# Altium schematic sheet nixie-tap-b.SchDoc
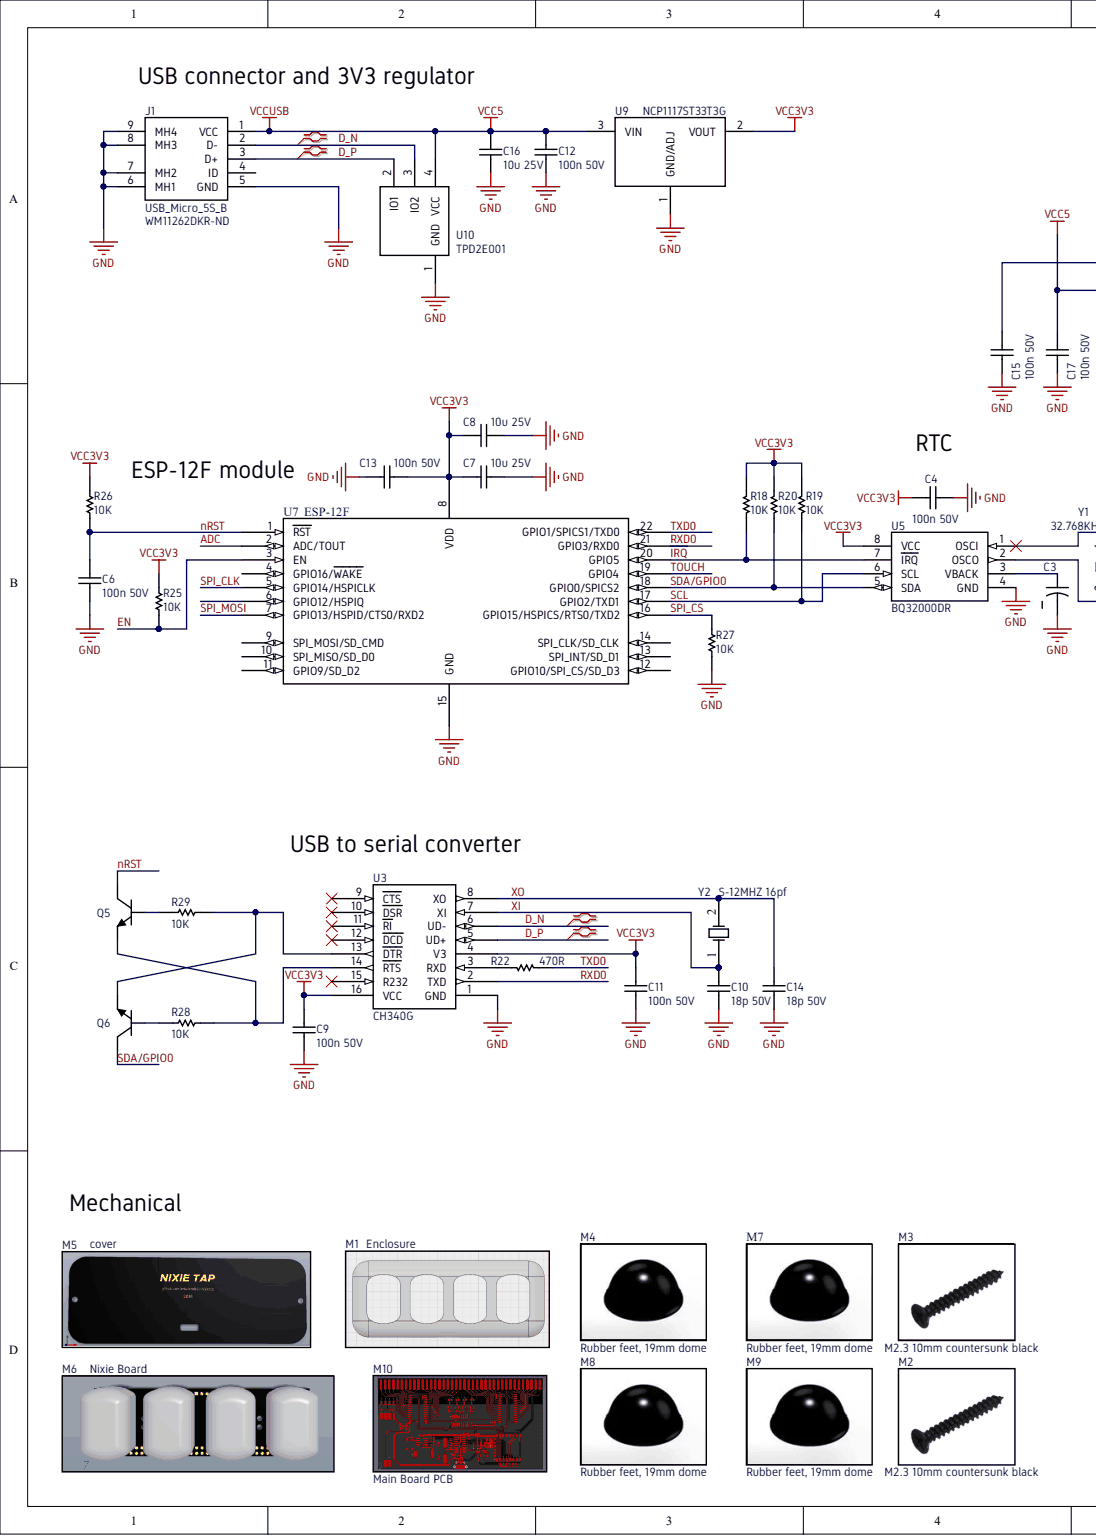
[diagram p0: nixie-tap-b.SchDoc, left]
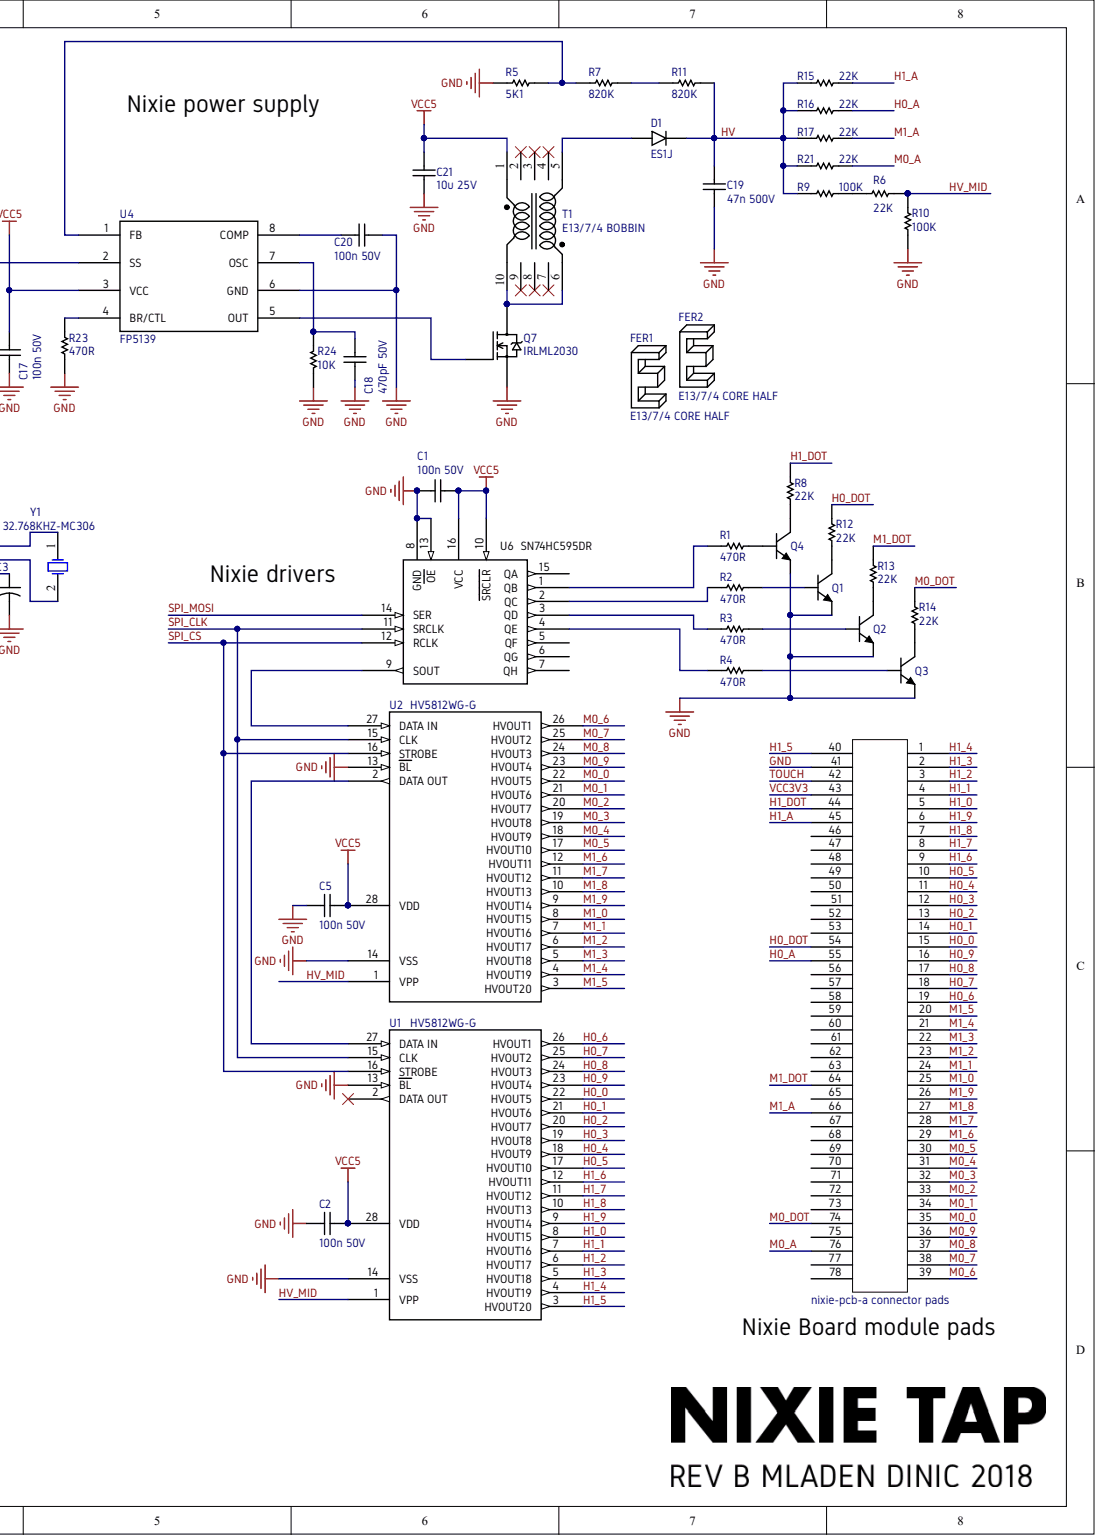
[diagram p1: nixie-tap-b.SchDoc, right]

## Parts
C1  100n 50V  value 100n  Voltage - Rated: 50V  [RESC2013X06L]
C2  100n 50V  value 100n  Voltage - Rated: 50V  [RESC2013X06L]
C3  ELNA DSK-3R3H224U-HL  [ELNA DSK-3R3H224U-HL]  (value not drawn)
C4  100n 50V  value 100n  Voltage - Rated: 50V  [RESC2013X06L]
C5  100n 50V  value 100n  Voltage - Rated: 50V  [RESC2013X06L]
C6  100n 50V  value 100n  [RESC2013X06L]
C7  10u 25V  Voltage - Rated: 25V  [RESC2013X06L]
C8  10u 25V  Voltage - Rated: 25V  [RESC2013X06L]
C9  100n 50V  value 100n  Voltage - Rated: 50V  [RESC2013X06L]
C10  18p 50V  Voltage - Rated: 50V  [RESC2013X06L]
C11  100n 50V  value 100n  Voltage - Rated: 50V  [RESC2013X06L]
C12  100n 50V  value 100n  [RESC2013X06L]
C13  100n 50V  value 100n  [RESC2013X06L]
C14  18p 50V  Voltage - Rated: 50V  [RESC2013X06L]
C15  100n 50V  value 100n  Voltage - Rated: 50V  [RESC2013X06L]
C16  10u 25V  [RESC2013X06L]
C17  100n 50V  value 100n  Voltage - Rated: 50V  [RESC2013X06L]
C18  470pF 50V  [RESC2013X06L]
C19  47n 500V  [CAP_1206]
C20  100n 50V  value 100n  Voltage - Rated: 50V  [RESC2013X06L]
C21  10u 25V  Voltage - Rated: 25V  [RESC2013X06L]
D1  ES1J  [DO-214AC]
FER1  E13/7/4 CORE HALF  (mechanical)
FER2  E13/7/4 CORE HALF  (mechanical)
J1  USB_Micro_5S_B  Supplier Part Number 1: WM11262DKR-ND  [USB_MICRO_5S_B]
M1  Enclosure  (mechanical)
M2  M2.3 10mm countersunk black  (mechanical)
M3  M2.3 10mm countersunk black  (mechanical)
M4  Rubber feet, 19mm dome  (mechanical)
M5  cover  (mechanical)
M6  Nixie Board  (mechanical)
M7  Rubber feet, 19mm dome  (mechanical)
M8  Rubber feet, 19mm dome  (mechanical)
M9  Rubber feet, 19mm dome  (mechanical)
M10  Main Board PCB  (mechanical)
Module1  nixie-pcb-a connector pads  [NB1.1]  (no BOM)
Q1  MMBTA42LT1G  [SOT95P245X110-3N]  (value not drawn)
Q2  MMBTA42LT1G  [SOT95P245X110-3N]  (value not drawn)
Q3  MMBTA42LT1G  [SOT95P245X110-3N]  (value not drawn)
Q4  MMBTA42LT1G  [SOT95P245X110-3N]  (value not drawn)
Q5  MMBTA42LT1G  [SOT95P245X110-3N]  (value not drawn)
Q6  MMBTA42LT1G  [SOT95P245X110-3N]  (value not drawn)
Q7  IRLML2030  [SOT_23]
R1  470R  [RESC2013X06L]
R2  470R  [RESC2013X06L]
R3  470R  [RESC2013X06L]
R4  470R  [RESC2013X06L]
R5  5K1  [RESC2013X06L]
R6  22K  [RESC2013X06L]
R7  820K  [RESC2013X06L]
R8  22K  [RESC2013X06L]
R9  100K  [RESC2013X06L]
R10  100K  [RESC2013X06L]
R11  820K  [RESC2013X06L]
R12  22K  [RESC2013X06L]
R13  22K  [RESC2013X06L]
R14  22K  [RESC2013X06L]
R15  22K  [RESC2013X06L]
R16  22K  [RESC2013X06L]
R17  22K  [RESC2013X06L]
R18  10K  [RESC2013X06L]
R19  10K  [RESC2013X06L]
R20  10K  [RESC2013X06L]
R21  22K  [RESC2013X06L]
R22  470R  [RESC2013X06L]
R23  470R  [RESC2013X06L]
R24  10K  [RESC2013X06L]
R25  10K  [RESC2013X06L]
R26  10K  [RESC2013X06L]
R27  10K  [RESC2013X06L]
R28  10K  [RESC2013X06L]
R29  10K  [RESC2013X06L]
T1  E13/7/4 BOBBIN  [E13/7/4]
U1  HV5812WG-G  [SOIC127P1030X265-28N]
U2  HV5812WG-G  [SOIC127P1030X265-28N]
U3  CH340G  [SOP-16]
U4  FP5139  [TSSOP8]
U5  BQ32000DR  [SOIC-8]
U6  SN74HC595DR  [SO16_M]
U7  ESP-12F  [ESP_12_E_L]
U9  NCP1117ST33T3G  [SOT-223]
U10  TPD2E001  [SOT190P237X120-4N]
Y1  Ordering Code: 32.768KHZ-MC306  [SOJ550P380X254-4M]  (hidden comment: MC306 32.768000KHZ 12.5PF)
Y2  S-12MHZ 16pf  [HC49-S]

## Nets
VCC5: C1.1 C2.1 C5.1 C12.2 C16.1 C17.1 C21.1 J1.1(VCC) T1.1 U1.28(VDD) U2.28(VDD) U4.3(VCC) U6.10(SRCLR) U6.16(VCC) U9.3 U10.4(VCC)
ADC: U7.2(ADC/TOUT)
D_N: J1.2(D-) U3.6(UD-) U10.3(IO2)
D_P: J1.3(D+) U3.5(UD+) U10.2(IO1)
EN: R25.1 U7.3(EN)
GND: C1.2 C2.2 C3.1 C4.2 C5.2 C6.1 C7.2 C8.2 C9.1 C10.1 C11.1 C12.1 C13.2 C14.1 C15.2 C16.2 C17.2 C18.1 C19.1 C20.1 C21.2 J1.5(GND) J1.6(MH1) J1.7(MH2) J1.8(MH3) J1.9(MH4) Module1.41 Q1.2 Q2.2 Q3.2 Q4.2 Q7.2 R5.1 R10.2 R23.2 R24.1 R27.1 U1.13(BL) U1.14(VSS) U2.13(BL) U2.14(VSS) U3.1(GND) U4.6(GND) U5.4(GND) U6.8(GND) U6.13(OE) U7.15(GND) U9.1 U10.1(GND)
H0_0: Module1.15 U1.22(HVOUT5)
H0_1: Module1.14 U1.21(HVOUT6)
H0_2: Module1.13 U1.20(HVOUT7)
H0_3: Module1.12 U1.19(HVOUT8)
H0_4: Module1.11 U1.18(HVOUT9)
H0_5: Module1.10 U1.17(HVOUT10)
H0_6: Module1.19 U1.26(HVOUT1)
H0_7: Module1.18 U1.25(HVOUT2)
H0_8: Module1.17 U1.24(HVOUT3)
H0_9: Module1.16 U1.23(HVOUT4)
H0_A: Module1.55 R16.1
H0_DOT: Module1.54 R12.2
H1_0: Module1.5 U1.8(HVOUT15)
H1_1: Module1.4 U1.7(HVOUT16)
H1_2: Module1.3 U1.6(HVOUT17)
H1_3: Module1.2 U1.5(HVOUT18)
H1_4: Module1.1 U1.4(HVOUT19)
H1_5: Module1.40 U1.3(HVOUT20)
H1_6: Module1.9 U1.12(HVOUT11)
H1_7: Module1.8 U1.11(HVOUT12)
H1_8: Module1.7 U1.10(HVOUT13)
H1_9: Module1.6 U1.9(HVOUT14)
H1_A: Module1.45 R15.1
H1_DOT: Module1.44 R8.2
HV: C19.2 D1.K R9.1 R11.2 R15.2 R16.2 R17.2 R21.2
HV_MID: R6.1 R10.1 U1.1(VPP) U2.1(VPP)
~{IRQ}: R18.1 U5.7(IRQ) U7.20(GPIO5)
M0_0: Module1.35 U2.22(HVOUT5)
M0_1: Module1.34 U2.21(HVOUT6)
M0_2: Module1.33 U2.20(HVOUT7)
M0_3: Module1.32 U2.19(HVOUT8)
M0_4: Module1.31 U2.18(HVOUT9)
M0_5: Module1.30 U2.17(HVOUT10)
M0_6: Module1.39 U2.26(HVOUT1)
M0_7: Module1.38 U2.25(HVOUT2)
M0_8: Module1.37 U2.24(HVOUT3)
M0_9: Module1.36 U2.23(HVOUT4)
M0_A: Module1.76 R21.1
M0_DOT: Module1.74 R14.2
M1_0: Module1.25 U2.8(HVOUT15)
M1_1: Module1.24 U2.7(HVOUT16)
M1_2: Module1.23 U2.6(HVOUT17)
M1_3: Module1.22 U2.5(HVOUT18)
M1_4: Module1.21 U2.4(HVOUT19)
M1_5: Module1.20 U2.3(HVOUT20)
M1_6: Module1.29 U2.12(HVOUT11)
M1_7: Module1.28 U2.11(HVOUT12)
M1_8: Module1.27 U2.10(HVOUT13)
M1_9: Module1.26 U2.9(HVOUT14)
M1_A: Module1.66 R17.1
M1_DOT: Module1.64 R13.2
NRST: C6.2 Q5.3 R26.1 U7.1(RST)
RXD0: U3.2(TXD) U7.21(GPIO3/RXD0)
SCL: R19.1 U5.6(SCL) U7.17(GPIO2/TXD1)
SDA/GPIO0: Q6.3 R20.1 U5.5(SDA) U7.18(GPIO0/SPICS2)
SPI_CLK: U1.15(CLK) U2.15(CLK) U6.11(SRCLK) U7.5(GPIO14/HSPICLK)
SPI_CS: R27.2 U1.16(STROBE) U2.16(STROBE) U6.12(RCLK) U7.16(GPIO15/HSPICS/RTS0/TXD2)
SPI_MOSI: U6.14(SER) U7.7(GPIO13/HSPID/CTS0/RXD2)
TOUCH: Module1.42 U7.19(GPIO4)
TXD0: R22.1 U7.22(GPIO1/SPICS1/TXD0)
VCC3V3: C4.1 C7.1 C8.1 C9.2 C11.2 C13.1 Module1.43 R18.2 R19.2 R20.2 R25.2 R26.2 U3.4(V3) U3.16(VCC) U5.8(VCC) U7.8(VDD) U9.2
XI: C10.2 U3.7(XI) Y2.1
XO: C14.2 U3.8(XO) Y2.2
NetC3_2: C3.2 U5.3(VBACK)
NetC15_1: C15.1 U4.2(SS)
NetC18_2: C18.2 R24.2 U4.7(OSC)
NetC20_2: C20.2 U4.8(COMP)
NetD1_A: D1.A T1.5
NetQ1_1: Q1.1 R2.1
NetQ1_3: Q1.3 R12.1
NetQ2_1: Q2.1 R3.1
NetQ2_3: Q2.3 R13.1
NetQ3_1: Q3.1 R4.1
NetQ3_3: Q3.3 R14.1
NetQ4_1: Q4.1 R1.1
NetQ4_3: Q4.3 R8.1
NetQ5_1: Q5.1 R29.2
NetQ5_2: Q5.2 R28.1 U3.14(RTS)
NetQ6_1: Q6.1 R28.2
NetQ6_2: Q6.2 R29.1 U3.13(DTR)
NetQ7_1: Q7.1 U4.5(OUT)
NetQ7_3: Q7.3 T1.6 T1.10
NetR1_2: R1.2 U6.1(QB)
NetR2_2: R2.2 U6.2(QC)
NetR3_2: R3.2 U6.3(QD)
NetR4_2: R4.2 U6.4(QE)
NetR5_2: R5.2 R7.1 U4.1(FB)
NetR6_2: R6.2 R9.2
NetR7_2: R7.2 R11.1
NetR22_2: R22.2 U3.3(RXD)
NetR23_1: R23.1 U4.4(BR/CTL)
NetU1_27: U1.27(DATA_IN) U2.2(DATA_OUT)
NetU2_27: U2.27(DATA_IN) U6.9(SOUT)
NetU5_1: U5.1(OSCI) Y1.1
NetU5_2: U5.2(OSCO) Y1.2

Not connected: J1 4(ID); Module1 46 47 48 49 50 51 52 53 56 57 58 59 60 61 62 63 65 67 68 69 70 71 72 73 75 77 78; T1 2 3 4 7 8 9; U1 2(DATA_OUT); U3 9(CTS) 10(DSR) 11(RI) 12(DCD) 15(R232); U6 5(QF) 6(QG) 7(QH) 15(QA); U7 4(GPIO16/WAKE) 6(GPIO12/HSPIQ) 9(SPI_MOSI/SD_CMD) 10(SPI_MISO/SD_D0) 11(GPIO9/SD_D2) 12(GPIO10/SPI_CS/SD_D3) 13(SPI_INT/SD_D1) 14(SPI_CLK/SD_CLK)

## Library data of the parts
C1 C2 C4-C6 C9 C11-C13 C15 C17 C20 = 100n 0805 50V: Manufacturer Part Number: CC0805KRX7R9BB104; Aavilable: YES; Notification: Current market demand for these product types have resulted in fluctuating and extending lead times. Lead times may differ.; Temperature Coefficient: X7R; Tolerance: ±10%; Applications: General Purpose; Category: Capacitors; Component Kind: Standard; ComponentLink1Description: http://www.yageo.com/documents/recent/UPY-GPHC_X7R_6.3V-to-50V_18.pdf; ComponentLink1URL: http://www.yageo.com/documents/recent/UPY-GPHC_X7R_6.3V-to-50V_18.pdf; Description: 100n 0805 50V; Failure Rate: -; Features: High Voltage; Height - Seated (Max): -; Implementation: YES; Lead Spacing: -; Lead Style: -; Mounting Type: Surface Mount, MLCC; Operating Temperature: -55°C ~ 125°C; Package / Case: 0805 (2012 Metric); Packaging: Digi-Reel®; Part Status: Active; Pricing 1: 1=0.1, 10=0.06, 100=0.0268, 500=0.01916, 1000=0.01505 (USD); Ratings: -; RoHS: RoHS Compliant; Size / Dimension: 0.079" L x 0.049" W (2.00mm x 1.25mm); Stock 1: 0; Supplier 1: Digi-Key; Supplier Part Number 1: 311-1140-6-ND; Thickness (Max): 0.033" (0.85mm); Library Name: Biblioteka-SMD.SchLib
  C6 C12 C13: Voltage - Rated: 50V
C3 = ELNA DSK-3R3H224U-HL: Supercap, SMD, 0.2F; Manufacturer: Elna America; ESR (Equivalent Series Resistance): 200 Ohm; Lifetime @ Temp.: 1000 Hrs @ 60°C; Tolerance: -20%, +80%; Category: Capacitors; Component Kind: Standard; ComponentLink1Description: http://media.digikey.com/pdf/Data%20Sheets/Elna%20America%20Inc/DS,DSK.pdf; ComponentLink1URL: http://media.digikey.com/pdf/Data%20Sheets/Elna%20America%20Inc/DS,DSK.pdf; Height - Seated (Max): 0.118" (3.00mm); Lead Spacing: -; Manufacturer Part Number: DSK-3R3H224U-HL; Mounting Type: Surface Mount; Operating Temperature: -10°C ~ 60°C; Package / Case: Coin, Wide Terminals - Opposite Sides; Packaging: Cut Tape (CT); Part Status: Active; Pricing 1: 1=1.89, 10=1.491, 100=1.118, 500=0.84474 (USD); RoHS: RoHS Compliant; Size / Dimension: 0.268" Dia (6.80mm); Stock 1: 110001; Supplier 1: Digi-Key; Supplier Part Number 1: 604-1020-1-ND; Termination: SMD (SMT) Tabs; Library Name: Biblioteka-SMD.SchLib
C7 C8 C16 C21 = 10u 0805 25V: Manufacturer: Yageo; Manufacturer Part Number: CC0805KKX5R8BB106; Notification: Current market demand for these product types have resulted in fluctuating and extending lead times. Lead times may differ.; Temperature Coefficient: X5R; Tolerance: ±10%; Applications: General Purpose; Category: Capacitors; Component Kind: Standard; ComponentLink1Description: http://www.yageo.com/documents/recent/UPY-GPHC_X5R_4V-to-50V_25.pdf; ComponentLink1URL: http://www.yageo.com/documents/recent/UPY-GPHC_X5R_4V-to-50V_25.pdf; Description: 10u 0805 25V; Failure Rate: -; Features: -; Height - Seated (Max): -; Lead Spacing: -; Lead Style: -; Mounting Type: Surface Mount, MLCC; Operating Temperature: -55°C ~ 85°C; Package / Case: 0805 (2012 Metric); Packaging: Digi-Reel®; Part Status: Active; Pricing 1: 1=0.26, 10=0.179, 100=0.1013, 500=0.07152, 1000=0.06257 (USD); Ratings: -; RoHS: RoHS Compliant; Size / Dimension: 0.079" L x 0.049" W (2.00mm x 1.25mm); Stock 1: 30856; Supplier 1: Digi-Key; Supplier Part Number 1: 311-1869-6-ND; Thickness (Max): 0.057" (1.45mm); Library Name: Biblioteka-SMD.SchLib
  C16: Voltage - Rated: 25V
C10 C14 = 18p 0805 50V: Manufacturer: Yageo; Manufacturer Part Number: CC0805JRNPO9BN180; Notification: Current market demand for these product types have resulted in fluctuating and extending lead times. Lead times may differ.; Temperature Coefficient: C0G, NP0; Tolerance: ±5%; Applications: General Purpose; Category: Capacitors; Component Kind: Standard; ComponentLink1Description: http://www.yageo.com/documents/recent/UPY-GP_NP0_16V-to-50V_16.pdf; ComponentLink1URL: http://www.yageo.com/documents/recent/UPY-GP_NP0_16V-to-50V_16.pdf; Description: 18p 0805 50V; Failure Rate: -; Features: High Voltage; Height - Seated (Max): -; Lead Spacing: -; Lead Style: -; Mounting Type: Surface Mount, MLCC; Operating Temperature: -55°C ~ 125°C; Package / Case: 0805 (2012 Metric); Packaging: Cut Tape (CT); Part Status: Active; Pricing 1: 1=0.1, 10=0.063, 100=0.0282, 500=0.02016, 1000=0.01584 (USD); Ratings: -; RoHS: RoHS Compliant; Size / Dimension: 0.079" L x 0.049" W (2.00mm x 1.25mm); Stock 1: 87490; Supplier 1: Digi-Key; Supplier Part Number 1: 311-1102-1-ND; Thickness (Max): 0.024" (0.60mm); Library Name: Biblioteka-SMD.SchLib
C18 = 470pF 0805: 470pF 50V X7R 10%; Component Kind: Standard; Supplier 1: Digi-Key; Supplier Part Number 1: 311-4279-1-ND; Library Name: Biblioteka-SMD.IntLib
C19 = 47n 500V 1206: Multilayer Ceramic Capacitors MLCC - SMD/SMT 500volts .047uF X7R 10%; Manufacturer: Kemet; Manufacturer Code: C1206C473KCRACTU; Ordering Code: 80-C1206C473KCRACTU; Component Kind: Standard; Distributor: Mouser; Supplier 1: Mouser; Supplier Part Number 1: 80-C1206C473KCRACTU; Library Name: Biblioteka-SMD.SchLib
D1 = ES1J: 1.0 A 600V Ultra Fast Recovery Rectifier; Manufacturer: ON semiconductor; Ordering Code: 512-ES1J; Component Kind: Standard; Distributor: Mouser; Manufacturer Code: ES1J; Supplier 1: Mouser; Supplier Part Number 1: 512-ES1J; Library Name: Biblioteka-SMD.IntLib
FER1 FER2 = E13/7/4 CORE HALF: 0.04mm gapped core E13/7/4 N27 LP 0.04MM; Manufacturer: EPCOS / TDK; Manufacturer Code: B66305G0040X127; Ordering Code: 871-B66305G0040X127; Distributor: Mouser; Supplier 1: Mouser; Supplier Part Number 1: 871-B66305G0040X127; Library Name: Biblioteka-SMD.IntLib
J1 = USB_Micro_5S_B: USB micro female SMT with 4 fixed foot; Manufacturer: Molex, LLC; Manufacturer Part Number: 1050171001; Connector Type: USB - micro B; Current Rating: 1A, 1.8A; Gender: Receptacle; Material Flammability Rating: UL94 V-0; Mating Cycles: 10000; Number of Contacts: 5; Shielding: Shielded; Specifications: USB 2.0; Voltage - Rated: 30VAC; Category: Connectors, Interconnects; Component Kind: Standard; ComponentLink1Description: https://www.molex.com/pdm_docs/sd/1050171001_sd.pdf; ComponentLink1URL: https://www.molex.com/pdm_docs/sd/1050171001_sd.pdf; Contact Finish: Gold; Features: Pick and Place, Solder Retention; Ingress Protection: -; Insulation Color: Black; Mounting Feature: Horizontal; Mounting Type: Surface Mount, Right Angle; Operating Temperature: -30°C ~ 85°C; Packaging: Digi-Reel®; Part Status: Active; Pricing 1: 1=1.53, 10=1.387, 25=1.3016, 50=1.245, 100=1.1885, 250=1.07532, 500=0.99042, 1000=0.84893 (USD); RoHS: RoHS Compliant; Shell/Shielding Material: Steel, Stainless; Stock 1: 14321; Supplier 1: Digi-Key; Termination: Solder; Library Name: Biblioteka-SMD.SchLib
M1 = nixie-tap-enclosure: Library Name: nixie-tap.SchLib; Pricing 1: 1=150, 50=70; Supplier 1: Kaierwo; Supplier Part Number: Nixie Tap 1
M2 M3 = nixie-tap-screw: Description: M2.3 10mm countersunk black; Library Name: nixie-tap.SchLib; Pricing 1: 1=0.012; Supplier 1: Generic; Supplier Part Number: M2.3 10mm countersunk black
M4 M7-M9 = nixie-tap-feet: Manufacturer: 3M; Manufacturer Part Number: SJ-5017 (BLACK); Form: Sheet; Hardness: 72 Shore M; Shape: Cylindrical, Dome; Category: Hardware, Fasteners, Accessories; Color: Black; ComponentLink1Description: https://multimedia.3m.com/mws/media/117314O/3m-bumpon-protective-products-molded-shapes-colored-and-clear.pdf; ComponentLink1URL: https://multimedia.3m.com/mws/media/117314O/3m-bumpon-protective-products-molded-shapes-colored-and-clear.pdf; Description: Rubber feet, 19mm dome; Library Name: nixie-tap.IntLib; Material: Polyurethane; Mounting Type: Adhesive, Rubber; Part Status: Active; Pricing 1: 40=0.31975, 80=0.306, 120=0.272, 280=0.25843, 520=0.24483, 1000=0.23123, 2520=0.22443, 5000=0.18363 (USD); RoHS: RoHS Compliant; Size / Dimension: 0.750" Dia (19.05mm); Stock 1: 13180; Supplier 1: Digi-Key; Supplier 2: Digi-Key; Supplier Part Number 1: SJ5017-0-ND; Supplier Part Number 2: SJ5017-0-ND; Thickness: 0.378" (9.60mm); Type: Bumper
M5 = nixie-tap-cover: Library Name: nixie-tap.IntLib
M6 = nixie-tap-nixieboard: Library Name: nixie-tap.IntLib
M10 = nixie-tap-main-pcb: Library Name: nixie-tap.IntLib
Module1 = Nixie Board 1.1: Description: Nixie Board 1.1; Library Name: Board Modules.SchLib
Q1-Q6 = MMBTA42LT1G: NPN High Voltage Transistor; Manufacturer: ON Semiconductor; Current - Collector (Ic) (Max): 500mA; Current - Collector Cutoff (Max): 100nA (ICBO); DC Current Gain (hFE) (Min) @ Ic, Vce: 40 @ 30mA, 10V; Frequency - Transition: 50MHz; Power - Max: 225mW; Vce Saturation (Max) @ Ib, Ic: 500mV @ 2mA, 20mA; Voltage - Collector Emitter Breakdown (Max): 300V; Category: Discrete Semiconductor Products; Component Kind: Standard; ComponentLink1Description: http://www.onsemi.com/pub_link/Collateral/MMBTA42LT1-D.PDF; ComponentLink1URL: http://www.onsemi.com/pub_link/Collateral/MMBTA42LT1-D.PDF; Manufacturer Part Number: MMBTA42LT1G; Mounting Type: Surface Mount; Package / Case: TO-236-3, SC-59, SOT-23-3; Packaging: Tape & Reel (TR); Part Number: MMBTA42LT1G; Pricing 1: 3000=0.03264, 6000=0.02944, 15000=0.0256, 30000=0.02304, 75000=0.02048, 150000=0.01702 (USD); RoHS: RoHS Compliant; Stock 1: 0; Supplier 1: Digi-Key; Supplier Device Package: SOT-23-3 (TO-236); Supplier Part Number 1: MMBTA42LT1GOSTR-ND; Transistor Type: NPN; Library Name: Biblioteka-SMD.SchLib
Q7 = IRLML2030: MOSFET MOSFT 30V 2.7A 100mOhm 1.0nC; Manufacturer: Infineon Technologies; Manufacturer Code: IRLML2030TRPBF; Ordering Code: 942-IRLML2030TRPBF; Component Kind: Standard; Distributor: Mouser; Supplier 1: Mouser; Supplier Part Number 1: 942-IRLML2030TRPBF; Library Name: Biblioteka-SMD.IntLib
R1-R4 R22 R23 = 470R 0805: Manufacturer: Yageo; Manufacturer Part Number: RC0805JR-07470RL; Power (Watts): 0.125W, 1/8W; Temperature Coefficient: ±100ppm/°C; Tolerance: ±5%; Category: Resistors; Component Kind: Standard; ComponentLink1Description: http://www.yageo.com/documents/recent/PYu-RC_Group_51_RoHS_L_8.pdf; ComponentLink1URL: http://www.yageo.com/documents/recent/PYu-RC_Group_51_RoHS_L_8.pdf; Composition: Thick Film; Description: 470R 0805; Failure Rate: -; Features: Moisture Resistant; Height - Seated (Max): 0.024" (0.60mm); Operating Temperature: -55°C ~ 155°C; Package / Case: 0805 (2012 Metric); Packaging: Digi-Reel®; Part Status: Active; Pricing 1: 1=0.1, 10=0.019, 100=0.0076, 1000=0.00341, 2500=0.00296 (USD); RoHS: RoHS Compliant; Size / Dimension: 0.079" L x 0.049" W (2.00mm x 1.25mm); Stock 1: 589476; Supplier 1: Digi-Key; Supplier Device Package: 0805; Supplier Part Number 1: 311-470ARDKR-ND; Library Name: Biblioteka-SMD.SchLib
R5 = 5K1 0805: 5K1 1% 0805; Component Kind: Standard; Supplier 1: TME; Supplier Part Number 1: RC0805FR-075K1; Library Name: Biblioteka-SMD.IntLib
R6 R8 R12-R17 R21 = 22k 0805: Manufacturer: Yageo; Manufacturer Part Number: RC0805JR-0722KL; Power (Watts): 0.125W, 1/8W; Temperature Coefficient: ±100ppm/°C; Tolerance: ±5%; Category: Resistors; Component Kind: Standard; ComponentLink1Description: http://www.yageo.com/documents/recent/PYu-RC_Group_51_RoHS_L_8.pdf; ComponentLink1URL: http://www.yageo.com/documents/recent/PYu-RC_Group_51_RoHS_L_8.pdf; Composition: Thick Film; Description: 22K 0805; Failure Rate: -; Features: Moisture Resistant; Height - Seated (Max): 0.024" (0.60mm); Operating Temperature: -55°C ~ 155°C; Package / Case: 0805 (2012 Metric); Packaging: Digi-Reel®; Part Status: Active; Pricing 1: 1=0.1, 10=0.019, 100=0.0076, 1000=0.00341, 2500=0.00296 (USD); RoHS: RoHS Compliant; Size / Dimension: 0.079" L x 0.049" W (2.00mm x 1.25mm); Stock 1: 276531; Supplier 1: Digi-Key; Supplier Device Package: 0805; Supplier Part Number 1: 311-22KARDKR-ND; Library Name: Biblioteka-SMD.SchLib
R7 R11 = 820K 0805: 820K 1% 0805; Component Kind: Standard; Supplier 1: TME; Supplier Part Number 1: SMD0805-820K; Library Name: Biblioteka-SMD.IntLib
R9 R10 = 100k 0805: Manufacturer: Yageo; Manufacturer Part Number: RC0805FR-07100KL; Power (Watts): 0.125W, 1/8W; Temperature Coefficient: ±100ppm/°C; Tolerance: ±1%; Category: Resistors; Component Kind: Standard; ComponentLink1Description: http://www.yageo.com/documents/recent/PYu-RC_Group_51_RoHS_L_8.pdf; ComponentLink1URL: http://www.yageo.com/documents/recent/PYu-RC_Group_51_RoHS_L_8.pdf; Composition: Thick Film; Description: 100K 0805; Failure Rate: -; Features: Moisture Resistant; Height - Seated (Max): 0.024" (0.60mm); Operating Temperature: -55°C ~ 155°C; Package / Case: 0805 (2012 Metric); Packaging: Digi-Reel®; Part Status: Active; Pricing 1: 1=0.1, 10=0.022, 100=0.0088, 1000=0.00396, 2500=0.00344 (USD); RoHS: RoHS Compliant; Size / Dimension: 0.079" L x 0.049" W (2.00mm x 1.25mm); Stock 1: 7327774; Supplier 1: Digi-Key; Supplier Device Package: 0805; Supplier Part Number 1: 311-100KCRDKR-ND; Library Name: Biblioteka-SMD.SchLib
R18-R20 R24-R29 = 10k 0805: Manufacturer: Yageo; Manufacturer Part Number: RC0805FR-0710KL; Power (Watts): 0.125W, 1/8W; Temperature Coefficient: ±100ppm/°C; Tolerance: ±1%; Category: Resistors; Component Kind: Standard; ComponentLink1Description: http://www.yageo.com/documents/recent/PYu-RC_Group_51_RoHS_L_8.pdf; ComponentLink1URL: http://www.yageo.com/documents/recent/PYu-RC_Group_51_RoHS_L_8.pdf; Composition: Thick Film; Description: 10K 0805; Failure Rate: -; Features: Moisture Resistant; Height - Seated (Max): 0.024" (0.60mm); Operating Temperature: -55°C ~ 155°C; Package / Case: 0805 (2012 Metric); Packaging: Cut Tape (CT); Part Status: Active; Pricing 1: 1=0.1, 10=0.022, 100=0.0088, 1000=0.00396, 2500=0.00344 (USD); RoHS: RoHS Compliant; Size / Dimension: 0.079" L x 0.049" W (2.00mm x 1.25mm); Stock 1: 19016794; Supplier 1: Digi-Key; Supplier Device Package: 0805; Supplier Part Number 1: 311-10.0KCRCT-ND; Library Name: Biblioteka-SMD.SchLib
T1 = E13/7/4 BOBBIN: Bobbin for E13/7/4 ferrite core; Manufacturer: EPCOS / TDK; Manufacturer Code: B66306C1010T001; Ordering Code: 871-B66306C1010T001; Component Kind: Standard; Distributor: Mouser; Supplier 1: Mouser; Supplier Part Number 1: 871-B66306C1010T001; Library Name: Biblioteka-SMD.IntLib
U1 U2 = HV5812WG-G: IC DISPLAY DVR 20CH 80V 28SOIC; Manufacturer: Microchip; Display Type: Vacuum Fluorescent (VF); Interface: Serial; Voltage - Supply: 4.5 V ~ 5.5 V; Category: Integrated Circuits (ICs); Component Kind: Standard; ComponentLink1Description: http://www.microchip.com/mymicrochip/filehandler.aspx?ddocname=en570713; ComponentLink1URL: http://www.microchip.com/mymicrochip/filehandler.aspx?ddocname=en570713; Configuration: -; Digits or Characters: -; Manufacturer Part Number: HV5812WG-G; Mounting Type: Surface Mount; Operating Temperature: -40°C ~ 125°C; Package / Case: 28-SOIC (0.295", 7.50mm Width); Packaging: Cut Tape (CT); Part Status: Active; Pricing 1: 1=2.32, 25=1.932, 100=1.7535 (USD); RoHS: RoHS Compliant; Stock 1: 3217; Supplier: Digikey; Supplier 1: Digi-Key; Supplier Device Package: 28-SO; Supplier Part Number: HV5812WG-GCT-ND; Supplier Part Number 1: HV5812WG-GCT-ND; Library Name: Biblioteka-SMD.SchLib
U3 = CH340G: CH340G USB to serial (UART) bridge; Component Kind: Standard; Supplier: Electrodragon; Supplier Part Number 1: CIC1030; Library Name: Biblioteka-SMD.SchLib
U4 = FP5139: Asynchronous Boost Controller; Manufacturer: Feeling Technology; Component Kind: Standard; Manufacturer Code: FP5139; Supplier 1: LCSC; Supplier Part Number 1: C88318; Library Name: Biblioteka-SMD.IntLib
U5 = BQ32000DR: IC RTC CLK/CALENDAR I2C 8-SOIC; Manufacturer: Texas Instruments; Current - Timekeeping (Max): 100µA @ 3.3V; Date Format: YY-MM-DD-dd; Interface: I²C, 2-Wire Serial; Time Format: HH:MM:SS (24 hr); Voltage - Supply: 3 V ~ 3.6 V; Voltage - Supply, Battery: 1.4 V ~ 3.6 V; Category: Integrated Circuits (ICs); Component Kind: Standard; ComponentLink1Description: http://www.ti.com/lit/ds/symlink/bq32000.pdf; ComponentLink1URL: http://www.ti.com/lit/ds/symlink/bq32000.pdf; Features: Leap Year, Square Wave Output, Trickle-Charger; Manufacturer Code: BQ32000DR; Manufacturer Part Number: BQ32000DR; Memory Size: -; Mounting Type: Surface Mount; Operating Temperature: -40°C ~ 85°C; Package / Case: 8-SOIC (0.154", 3.90mm Width); Package Description: SOIC-8; Packaging: Cut Tape (CT); Part Status: Active; Pricing 1: 1=2.05, 10=1.842, 100=1.4809, 500=1.21668, 1000=1.00811 (USD); RoHS: RoHS Compliant; Stock 1: 3974; Supplier: Digi-Key; Supplier 1: Digi-Key; Supplier Device Package: 8-SOIC; Supplier Part Number: BQ32000DR; Supplier Part Number 1: 296-24583-1-ND; Type: Clock/Calendar; Library Name: Biblioteka-SMD.SchLib
U6 = SN74HC595DR: IC 8BIT SHIFT REG 3ST-OUT 16SOIC; Manufacturer: Texas Instruments; Function: Serial to Parallel, Serial; Logic Type: Shift Register; Number of Bits per Element: 8; Number of Elements: 1; Output Type: Tri-State; Voltage - Supply: 2 V ~ 6 V; Category: Integrated Circuits (ICs); Component Kind: Standard; ComponentLink1Description: http://www.ti.com/lit/ds/symlink/sn74hc595.pdf; ComponentLink1URL: http://www.ti.com/lit/ds/symlink/sn74hc595.pdf; Distributor: Digikey; Distributor Part Number: 296-14857-6-ND; Manufacturer Part Number: SN74HC595DR; Mounting Type: Surface Mount; Operating Temperature: -40°C ~ 85°C; Package / Case: 16-SOIC (0.154", 3.90mm Width); Packaging: Digi-Reel®; Pricing 1: 1=0.57, 10=0.433, 100=0.228, 1000=0.204 (USD); RoHS: RoHS Compliant; Stock 1: 12634; Supplier 1: Digi-Key; Supplier Device Package: 16-SOIC N; Supplier Part Number 1: 296-14857-6-ND; Library Name: Biblioteka-SMD.IntLib
U7 = ESP-12F: ESP-12F Wi-Fi Module by AI-Thinker; Component Kind: Standard; IC: ESP-12F; Supplier 1: LCSC; Supplier Part Number 1: C82891; Vendor: Ai-thinker; Library Name: Biblioteka-SMD.IntLib
U9 = NCP1117: NCP1117, NCV1117. 1.0 A Low-Dropout Positive  Fixed and Adjustable  Voltage Regulators; Manufacturer: ON Semiconductor; Manufacturer Part Number: NCP1117LPST50T3G; Current - Output: 1A; Protection Features: Over Current, Over Temperature; PSRR: 60dB (120Hz); Voltage - Input (Max): 18V; Voltage - Output (Min/Fixed): 5V; Voltage Dropout (Max): 1.4V @ 1A; Category: Integrated Circuits (ICs); Component Kind: Standard; ComponentLink1Description: http://www.onsemi.com/pub/Collateral/NCP1117LP-D.PDF; ComponentLink1URL: http://www.onsemi.com/pub/Collateral/NCP1117LP-D.PDF; Control Features: -; Mounting Type: Surface Mount; Operating Temperature: 0°C ~ 125°C; Output Configuration: Positive; Output Type: Fixed; Package / Case: TO-261-4, TO-261AA; Packaging: Cut Tape (CT); Part Status: Active; Pricing 1: 1=0.43, 10=0.351, 100=0.239, 500=0.17924, 1000=0.13442 (USD); RoHS: RoHS Compliant; Stock 1: 22212; Supplier 1: Digi-Key; Supplier Device Package: SOT-223; Supplier Part Number 1: NCP1117LPST50T3GOSCT-ND; Voltage - Output (Max): -; Library Name: Biblioteka-SMD.SchLib
U10 = TPD2E001DZDR: TVS DIODE 5.5VWM 100VC 4SOP; Manufacturer: Texas Instruments; Power Line Protection: Yes; Voltage - Breakdown (Min): 11V; Voltage - Reverse Standoff (Typ): 5.5V (Max); Applications: Ethernet; Base Part Number: TPD2E001; Capacitance @ Frequency: -; Category: Circuit Protection; Component Kind: Standard; ComponentLink1Description: http://www.ti.com/lit/ds/symlink/tpd2e001.pdf; ComponentLink1URL: http://www.ti.com/lit/ds/symlink/tpd2e001.pdf; Current - Peak Pulse (10/1000µs): -; Manufacturer Part Number: TPD2E001DZDR; Mounting Type: Surface Mount; Operating Temperature: -40°C ~ 85°C (TA); Package / Case: TO-253-4, TO-253AA; Packaging: Cut Tape (CT); Part Status: Active; Power - Peak Pulse: -; Pricing 1: 1=0.58, 10=0.494, 100=0.3692, 500=0.29004, 1000=0.22413 (USD); RoHS: RoHS Compliant; Stock 1: 282; Supplier 1: Digi-Key; Supplier Device Package: 4-SOP; Supplier Part Number 1: 296-24770-1-ND; Type: Steering (Rail to Rail); Voltage - Clamping (Max) @ Ipp: -; Library Name: Biblioteka-SMD.SchLib
Y1 = MC306 32.768000KHZ 12.5PF: Manufacturer: Epson; Frequency: 32.768kHz; MinAmount: 1; Resonator tolerance: ±20ppm; Resonator type: watch; SuppliedAmount: 0; Unit: pcs; Value: 32.768 kHz; Body dimensions: 8 x 3.2 x 2.38mm; Category: SMD Quartz Crystals; Component Kind: Standard; ComponentLink1Description: http://www.tme.eu/en/details/32.768khz-mc306/smd-quartz-crystals/epson/mc306-32768000khz-125pf/; ComponentLink1URL: http://www.tme.eu/en/details/32.768khz-mc306/smd-quartz-crystals/epson/mc306-32768000khz-125pf/; Description: MC306 32.768000KHZ 12.5PF; Distributor: TME; Manufacturer Part Number: MC306 32.768000KHZ 12.5PF; Mounting: SMD; Multiples: 1; Package Description: SOJ-4; Price Type: GROSS; Pricing 1: 1=0.4643, 10=0.3051, 100=0.2388, 1000=0.2098, 30000=0.1924 (EUR); Stock 1: 3229; Supplier 1: TME; Supplier Part Number 1: 32.768KHZ-MC306; Weight: 0.321; Library Name: Biblioteka-SMD.IntLib
Y2 = S-12MHZ 16pf: XTAL, 12.000MHZ, 16PF, SMD, HC-49S; Manufacturer: IQD; Manufacturer Code: LFXTAL003210Reel; Value: 12MHz; Component Kind: Standard; Package Description: HC-49S; Supplier 1: Mouser; Supplier Part Number 1: 449-LFXTAL003210REEL; Library Name: Biblioteka-SMD.IntLib
